# Altium schematic sheet Safety.SchDoc
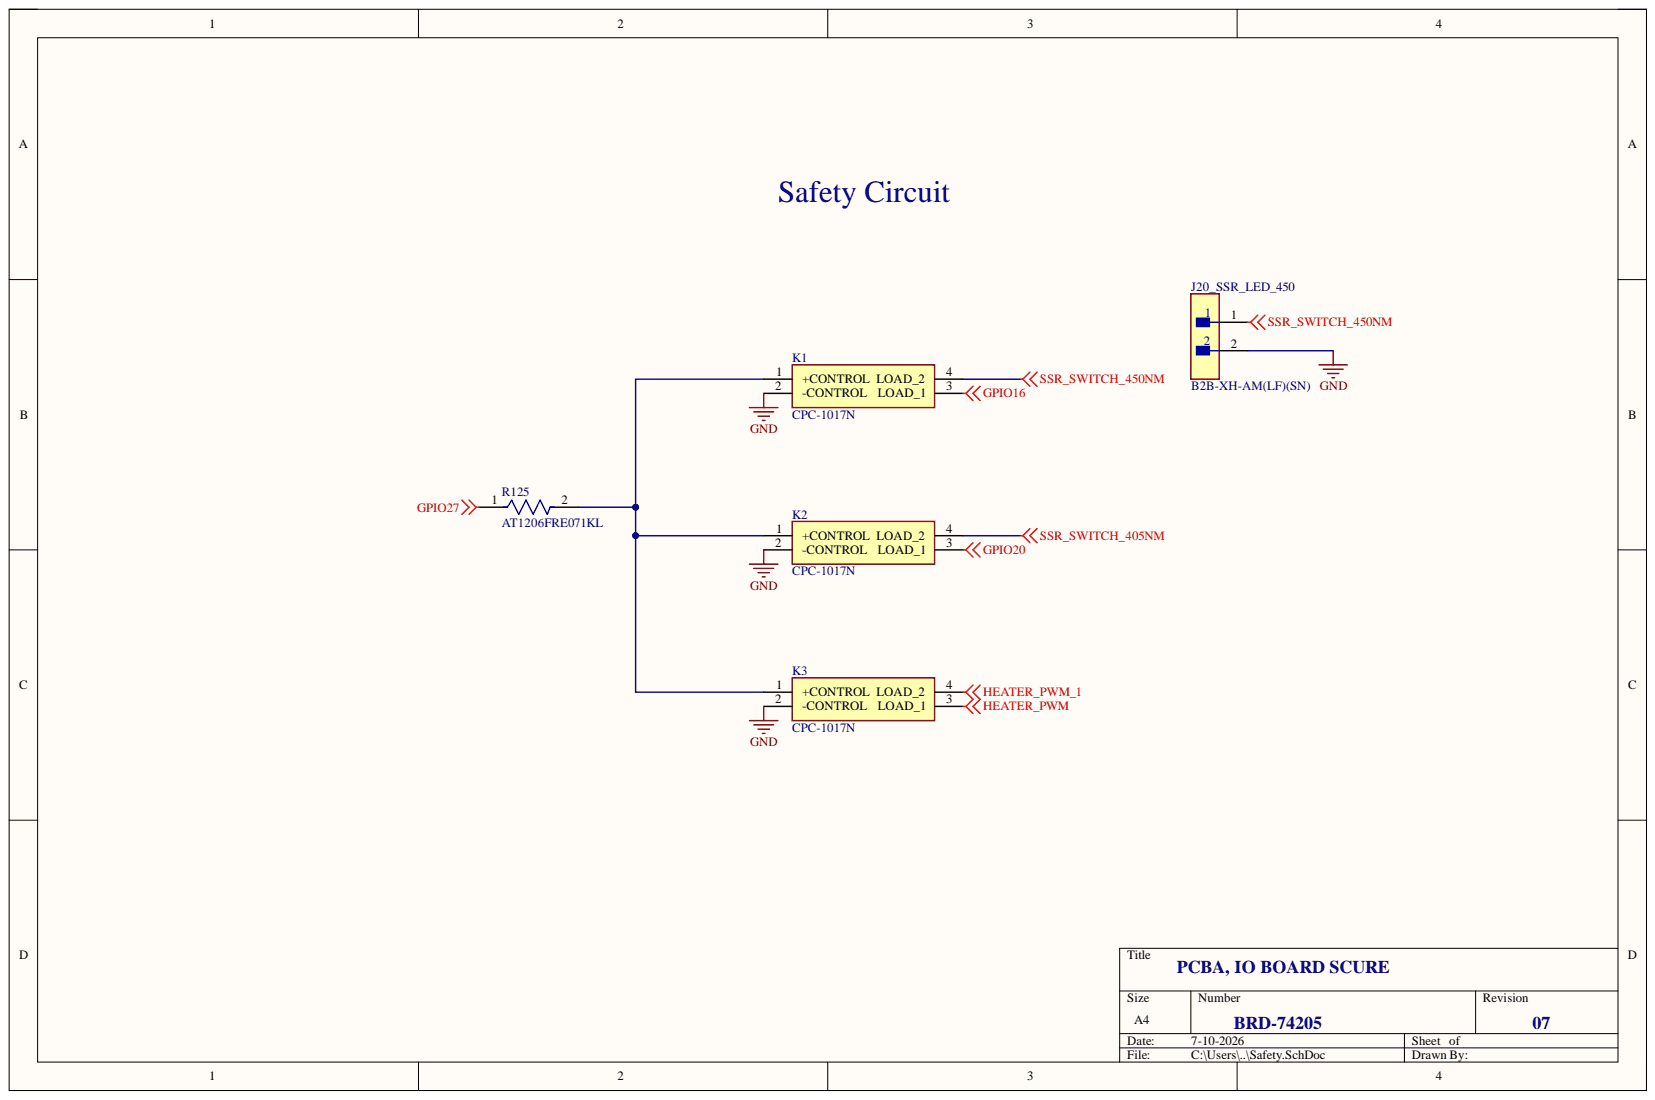
[diagram p0: Safety.SchDoc]

## Parts
J20_SSR_LED_450  B2B-XH-AM(LF)(SN)  [FP-B2B-XH-AM_LF_SN-MFG]
K1  CPC-1017N  [SOP254P610X206-4N]
K2  CPC-1017N  [SOP254P610X206-4N]
K3  CPC-1017N  [SOP254P610X206-4N]
R125  AT1206FRE071KL  [FP-AT1206-IPC_C]

## Nets
GND: J20_SSR_LED_450.2 K1.2(-CONTROL) K2.2(-CONTROL) K3.2(-CONTROL)
GPIO16: K1.3(LOAD_1)
GPIO20: K2.3(LOAD_1)
GPIO27: R125.1
HEATER_PWM: K3.3(LOAD_1)
HEATER_PWM_1: K3.4(LOAD_2)
SSR_SWITCH_405NM: K2.4(LOAD_2)
SSR_SWITCH_450NM: J20_SSR_LED_450.1 K1.4(LOAD_2)
NetK1_1: K1.1(+CONTROL) K2.1(+CONTROL) K3.1(+CONTROL) R125.2

## Library data of the parts
J20_SSR_LED_450 = CMP-17439-000037-1: CONN HEADER VERT 2POS 2.5MM; Connector Type: Male Pin; Contact Resistance: 20mR; Max Current Rating: 3A; Max Voltage Rating (AC): 250V; Number of Positions: 2; Color: Green; Component Kind: Standard; Contact Material: Brass; Contact Plating: Tin; Contact Type: Male Pin; Depth: 5.75mm; Fastening Type: Detent; Housing Color: White; Housing Material: Polyamide; Lead Free: Lead Free; Length: 7.4mm; Library Name: Altium Content Vault; Max Operating Temperature: 85°C; Min Operating Temperature: -25°C; Mount: Through Hole; Number of Rows: 1; Orientation: Straight; Packaging: Bulk; Pitch: 2.5mm; Series: XH; Termination: Solder
K1-K3 = CPC-1017N: Relay or Contactor; Manufacturer_Name: LITTELFUSE; Component Kind: Standard; Datasheet Link: http://www.ixysic.com/home/pdfs.nsf/www/CPC1017N.pdf/$file/CPC1017N.pdf; Height: 2.055mm; Manufacturer_Part_Number: CPC-1017N
R125 = CMP-03412-039742-1: Thin Film Chip Resistors Automotive Grade 1kΩ 1% 50ppm/°C 1206; Component Kind: Standard; Library Name: Altium Content Vault
